# Altium schematic sheet IO_Converter.SchDoc(IO_Converter)
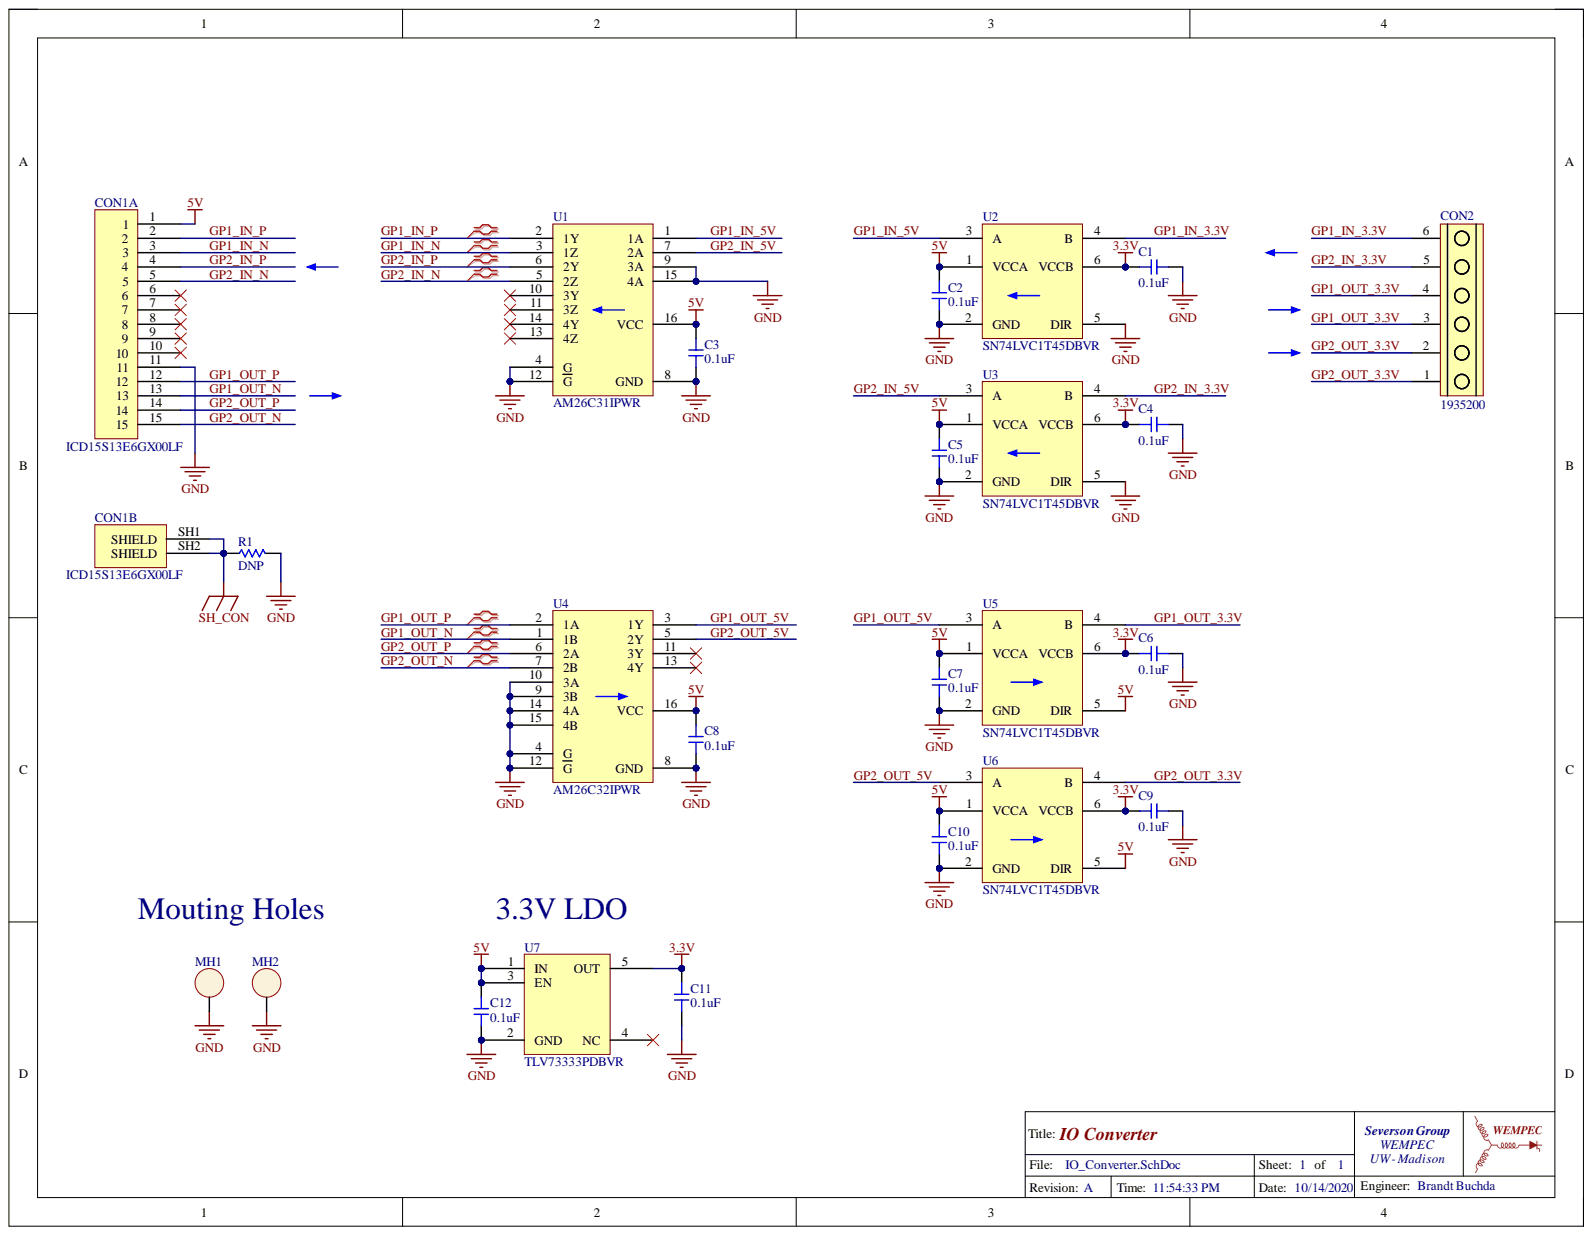
[diagram p0: IO_Converter.SchDoc]

## Parts
C1  value 0.1uF  [0603-CAP]  (hidden comment: CC0603KRX7R8BB104)
C2  value 0.1uF  [0603-CAP]  (hidden comment: CC0603KRX7R8BB104)
C3  value 0.1uF  [0603-CAP]  (hidden comment: CC0603KRX7R8BB104)
C4  value 0.1uF  [0603-CAP]  (hidden comment: CC0603KRX7R8BB104)
C5  value 0.1uF  [0603-CAP]  (hidden comment: CC0603KRX7R8BB104)
C6  value 0.1uF  [0603-CAP]  (hidden comment: CC0603KRX7R8BB104)
C7  value 0.1uF  [0603-CAP]  (hidden comment: CC0603KRX7R8BB104)
C8  value 0.1uF  [0603-CAP]  (hidden comment: CC0603KRX7R8BB104)
C9  value 0.1uF  [0603-CAP]  (hidden comment: CC0603KRX7R8BB104)
C10  value 0.1uF  [0603-CAP]  (hidden comment: CC0603KRX7R8BB104)
C11  value 0.1uF  [0603-CAP]  (hidden comment: CC0603KRX7R8BB104)
C12  value 0.1uF  [0603-CAP]  (hidden comment: CC0603KRX7R8BB104)
CON1  ICD15S13E6GX00LF  [ICD15S13E6GX00LF]  (parts A,B)
CON2  1935200  [1935200]
MH1  MOUNT_HOLE  [MOUNTING_HOLE]  (value not drawn)
MH2  MOUNT_HOLE  [MOUNTING_HOLE]  (value not drawn)
R1  value DNP  [0603-RES]  (hidden comment: Resistor)  (no BOM)
U1  AM26C31IPWR  [TSOP65P640X120-16N]
U2  SN74LVC1T45DBVR  [SOT95P280X145-6N]
U3  SN74LVC1T45DBVR  [SOT95P280X145-6N]
U4  AM26C32IPWR  [TSOP65P640X120-16N]
U5  SN74LVC1T45DBVR  [SOT95P280X145-6N]
U6  SN74LVC1T45DBVR  [SOT95P280X145-6N]
U7  TLV73333PDBVR  [SOT95P280X145-5N]

## Nets
3.3V: C1.1 C4.1 C6.1 C9.1 C11.2 U2.6(VCCB) U3.6(VCCB) U5.6(VCCB) U6.6(VCCB) U7.5(OUT)
5V: C2.2 C3.2 C5.2 C7.2 C8.2 C10.2 C12.2 CON1.1 U1.16(VCC) U2.1(VCCA) U3.1(VCCA) U4.16(VCC) U5.1(VCCA) U5.5(DIR) U6.1(VCCA) U6.5(DIR) U7.1(IN) U7.3(EN)
GND: C1.2 C2.1 C3.1 C4.2 C5.1 C6.2 C7.1 C8.1 C9.2 C10.1 C11.1 C12.1 CON1.11 MH1.1 MH2.1 R1.2 U1.4(G) U1.8(GND) U1.9(3A) U1.12(G) U1.15(4A) U2.2(GND) U2.5(DIR) U3.2(GND) U3.5(DIR) U4.4(G) U4.8(GND) U4.9(3B) U4.10(3A) U4.12(G) U4.14(4A) U4.15(4B) U5.2(GND) U6.2(GND) U7.2(GND)
SH_CON: CON1.SH1(SHIELD) CON1.SH2(SHIELD) R1.1
GP1_IN_3.3V: CON2.6 U2.4(B)
GP1_IN_5V: U1.1(1A) U2.3(A)
GP1_IN_N: CON1.3 U1.3(1Z)
GP1_IN_P: CON1.2 U1.2(1Y)
GP1_OUT_3.3V: CON2.3 CON2.4 U5.4(B)
GP1_OUT_5V: U4.3(1Y) U5.3(A)
GP1_OUT_N: CON1.13 U4.1(1B)
GP1_OUT_P: CON1.12 U4.2(1A)
GP2_IN_3.3V: CON2.5 U3.4(B)
GP2_IN_5V: U1.7(2A) U3.3(A)
GP2_IN_N: CON1.5 U1.5(2Z)
GP2_IN_P: CON1.4 U1.6(2Y)
GP2_OUT_3.3V: CON2.1 CON2.2 U6.4(B)
GP2_OUT_5V: U4.5(2Y) U6.3(A)
GP2_OUT_N: CON1.15 U4.7(2B)
GP2_OUT_P: CON1.14 U4.6(2A)

Not connected: CON1 6 7 8 9 10; U1 10(3Y) 11(3Z) 13(4Z) 14(4Y); U4 11(3Y) 13(4Y); U7 4(NC)

## Library data of the parts
C1-C12 = Capacitor 0.1uF: 0.1µF ±10% 25V Ceramic Capacitor X7R 0603 (1608 Metric); Component Kind: Standard; Simulation: CAP; Supplier 1: Digi-Key; Supplier Part Number 1: 311-1341-1-ND
  C2 C5 C7 C10: Library Name: IO_Converter.SchLib
  C1 C3 C4 C6 C8 C9 C11 C12: Library Name: CRAMB.SchLib
CON1 = ICD15S13E6GX00LF: 15 Position D-Sub, High Density Receptacle, Female Sockets Connector; Component Kind: Standard; Supplier 1: Digi-Key; Supplier Part Number 1: 609-2801-ND; Library Name: CRAMB.SchLib
CON2 = 1935200: 6 Position Wire to Board Terminal Block Horizontal with Board 0.197" (5.00mm) Through Hole; Component Kind: Standard; Supplier 1: Digi-Key; Supplier Part Number 1: 277-1581-ND; Library Name: CRAMB.SchLib
MH1 MH2 = MOUNT_HOLE: MOUNTING HOLE; Component Kind: Standard; Library Name: IO_Converter.SchLib
R1 = Resistor: DNP; Simulation: RES; Supplier 1: Digi-Key; Library Name: CRAMB.SchLib
U1 = AM26C31IPWR: IC RECEIVER 0/4 16TSSOP; Component Kind: Standard; Library Name: IO_Converter.SchLib; Supplier 1: Digi-Key; Supplier Part Number 1: 296-30087-1-ND
U2 U3 U5 U6 = SN74LVC1T45DBVR: IC TRNSLTR BIDIRECTIONAL SOT23-6; Component Kind: Standard; Library Name: IO_Converter.SchLib; Supplier 1: Digi-Key; Supplier Part Number 1: 296-16843-1-ND
U4 = AM26C32IPWR: IC RECEIVER 0/4 16TSSOP; Component Kind: Standard; Library Name: IO_Converter.SchLib; Supplier 1: Digi-Key; Supplier Part Number 1: 296-30088-1-ND
U7 = TLV73333PDBVR: IC REG LINEAR 3.3V 300MA SOT23-5; Component Kind: Standard; Library Name: IO_Converter.SchLib; Supplier 1: Digi-Key; Supplier Part Number 1: 296-40673-1-ND
